# Altium schematic sheet RelayCard_LED_Monitor.SchDoc
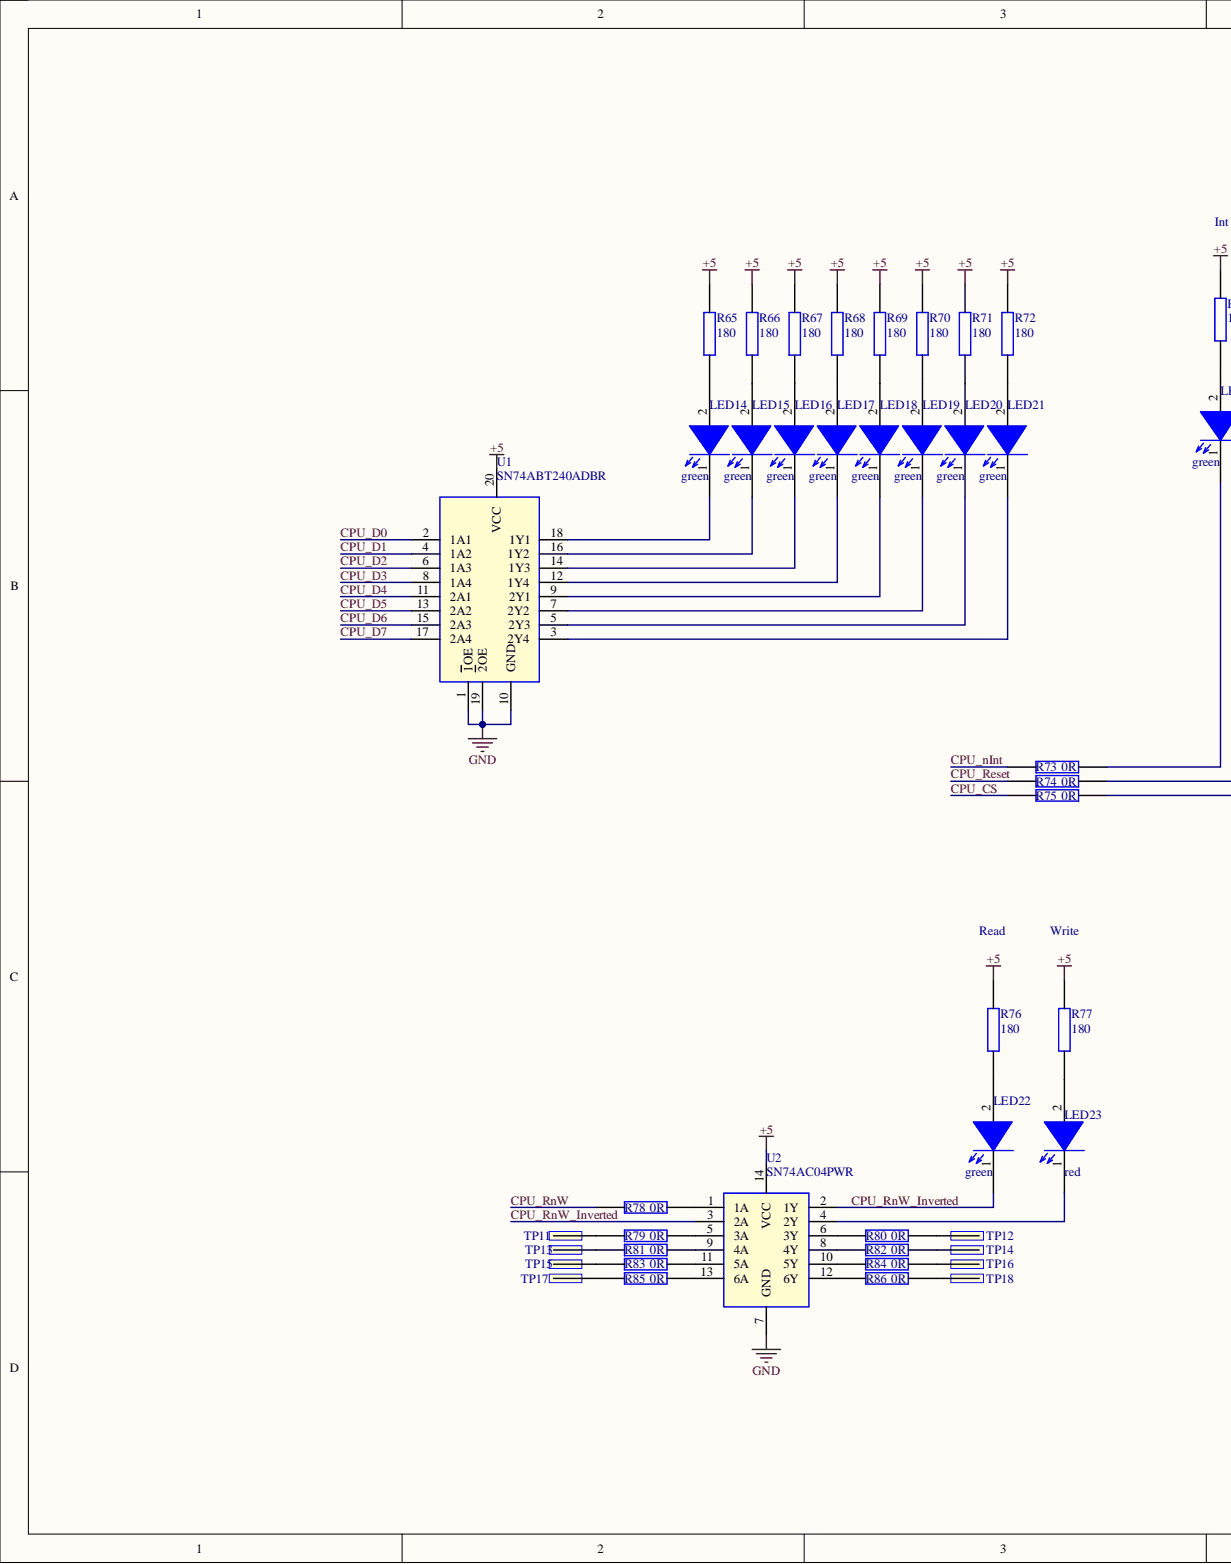
[diagram p0: RelayCard_LED_Monitor.SchDoc, left]
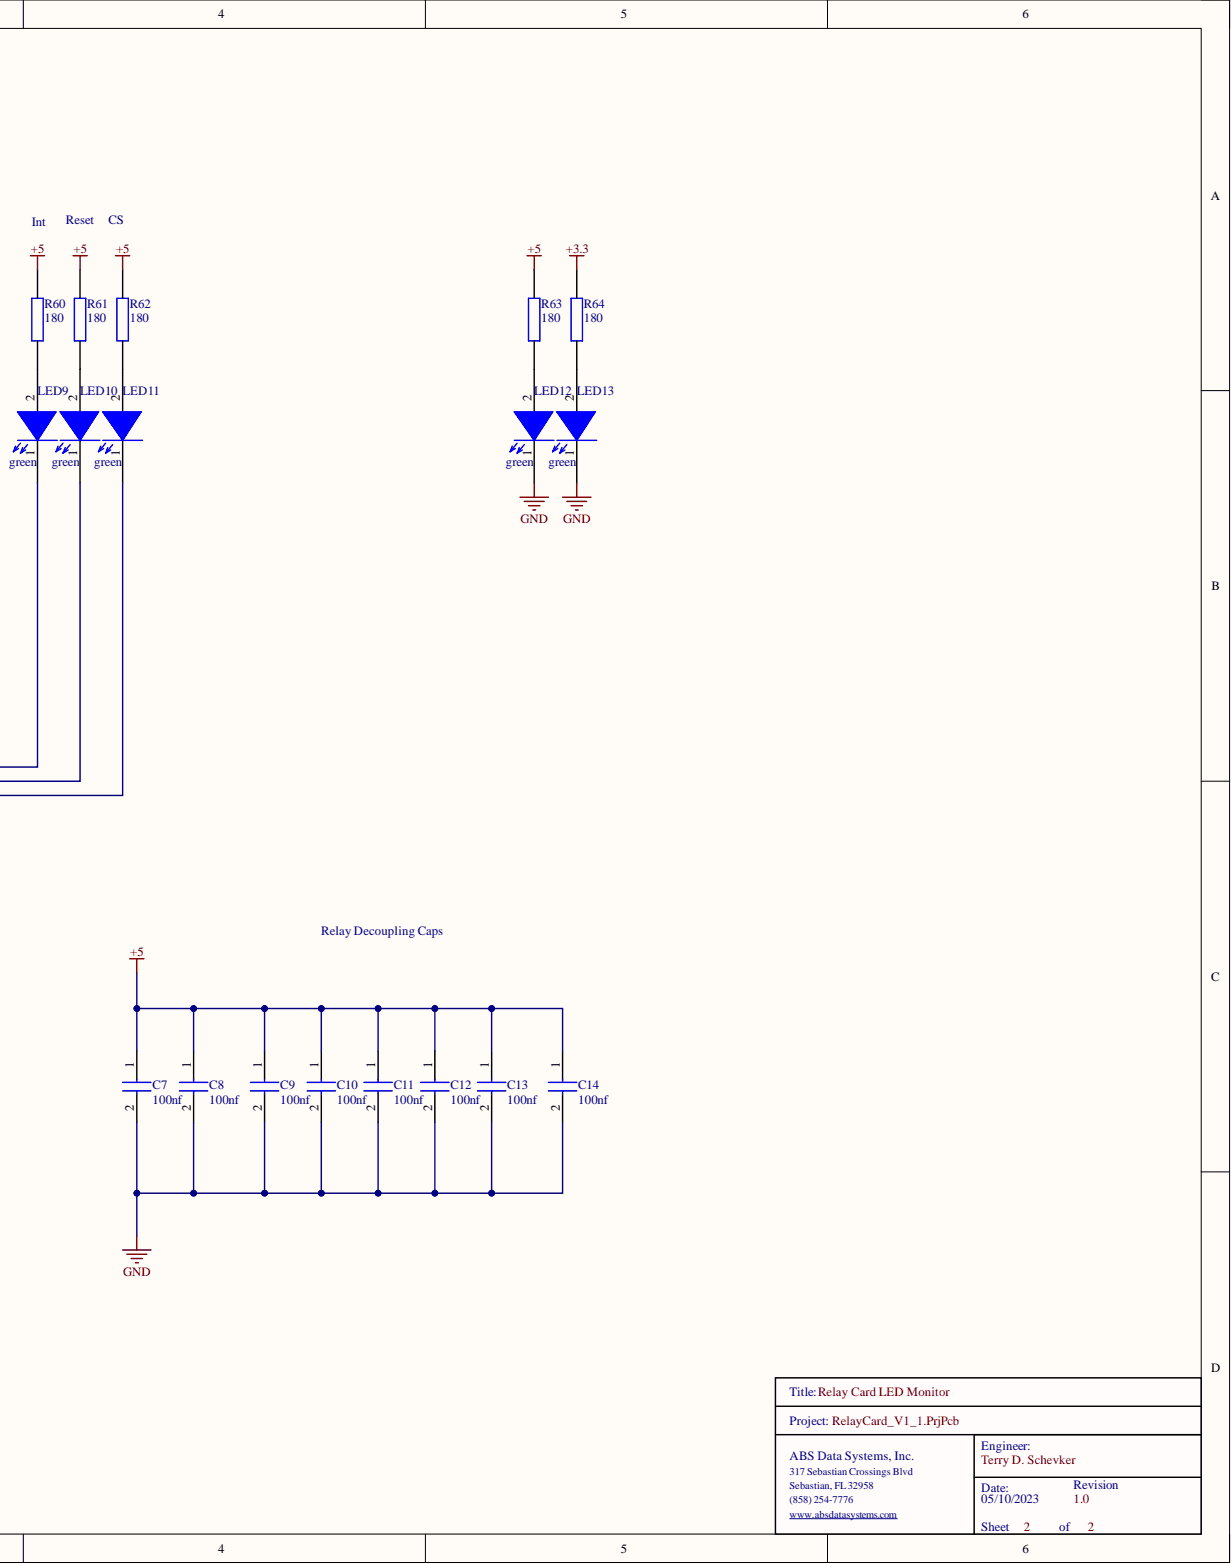
[diagram p1: RelayCard_LED_Monitor.SchDoc, right]

## Parts
C7  100nf  [0603]
C8  100nf  [0603]
C9  100nf  [0603]
C10  100nf  [0603]
C11  100nf  [0603]
C12  100nf  [0603]
C13  100nf  [0603]
C14  100nf  [0603]
LED9  green  [0603_Diode]
LED10  green  [0603_Diode]
LED11  green  [0603_Diode]
LED12  green  [0603_Diode]
LED13  green  [0603_Diode]
LED14  green  [0603_Diode]
LED15  green  [0603_Diode]
LED16  green  [0603_Diode]
LED17  green  [0603_Diode]
LED18  green  [0603_Diode]
LED19  green  [0603_Diode]
LED20  green  [0603_Diode]
LED21  green  [0603_Diode]
LED22  green  [0603_Diode]
LED23  red  [0603_Diode]
R60  180  [0603]
R61  180  [0603]
R62  180  [0603]
R63  180  [0603]
R64  180  [0603]
R65  180  [0603]
R66  180  [0603]
R67  180  [0603]
R68  180  [0603]
R69  180  [0603]
R70  180  [0603]
R71  180  [0603]
R72  180  [0603]
R73  0R  [0603]
R74  0R  [0603]
R75  0R  [0603]
R76  180  [0603]
R77  180  [0603]
R78  0R  [0603]
R79  0R  [0603]
R80  0R  [0603]
R81  0R  [0603]
R82  0R  [0603]
R83  0R  [0603]
R84  0R  [0603]
R85  0R  [0603]
R86  0R  [0603]
TP11  TP  [TP]
TP12  TP  [TP]
TP13  TP  [TP]
TP14  TP  [TP]
TP15  TP  [TP]
TP16  TP  [TP]
TP17  TP  [TP]
TP18  TP  [TP]
U1  SN74ABT240ADBR  [SOP65P780X200-20N]
U2  SN74AC04PWR  [SOP65P640X120-14N]

## Nets
+3.3: R64.2
+5: C7.1 C8.1 C9.1 C10.1 C11.1 C12.1 C13.1 C14.1 R60.2 R61.2 R62.2 R63.2 R65.2 R66.2 R67.2 R68.2 R69.2 R70.2 R71.2 R72.2 R76.2 R77.2 U1.20(VCC) U2.14(VCC)
GND: C7.2 C8.2 C9.2 C10.2 C11.2 C12.2 C13.2 C14.2 LED12.1 LED13.1 U1.1(1OE) U1.10(GND) U1.19(2OE) U2.7(GND)
CPU_CS: R75.1
CPU_D0: U1.2(1A1)
CPU_D1: U1.4(1A2)
CPU_D2: U1.6(1A3)
CPU_D3: U1.8(1A4)
CPU_D4: U1.11(2A1)
CPU_D5: U1.13(2A2)
CPU_D6: U1.15(2A3)
CPU_D7: U1.17(2A4)
CPU_nInt: R73.1
CPU_Reset: R74.1
CPU_RnW: R78.1
CPU_RnW_Inverted: LED22.1 U2.2(1Y) U2.3(2A)
NetLED9_1: LED9.1 R73.2
NetLED9_2: LED9.2 R60.1
NetLED10_1: LED10.1 R74.2
NetLED10_2: LED10.2 R61.1
NetLED11_1: LED11.1 R75.2
NetLED11_2: LED11.2 R62.1
NetLED12_2: LED12.2 R63.1
NetLED13_2: LED13.2 R64.1
NetLED14_1: LED14.1 U1.18(1Y1)
NetLED14_2: LED14.2 R65.1
NetLED15_1: LED15.1 U1.16(1Y2)
NetLED15_2: LED15.2 R66.1
NetLED16_1: LED16.1 U1.14(1Y3)
NetLED16_2: LED16.2 R67.1
NetLED17_1: LED17.1 U1.12(1Y4)
NetLED17_2: LED17.2 R68.1
NetLED18_1: LED18.1 U1.9(2Y1)
NetLED18_2: LED18.2 R69.1
NetLED19_1: LED19.1 U1.7(2Y2)
NetLED19_2: LED19.2 R70.1
NetLED20_1: LED20.1 U1.5(2Y3)
NetLED20_2: LED20.2 R71.1
NetLED21_1: LED21.1 U1.3(2Y4)
NetLED21_2: LED21.2 R72.1
NetLED22_2: LED22.2 R76.1
NetLED23_1: LED23.1 U2.4(2Y)
NetLED23_2: LED23.2 R77.1
NetR78_2: R78.2 U2.1(1A)
NetR79_1: R79.1 TP11.1
NetR79_2: R79.2 U2.5(3A)
NetR80_1: R80.1 U2.6(3Y)
NetR80_2: R80.2 TP12.1
NetR81_1: R81.1 TP13.1
NetR81_2: R81.2 U2.9(4A)
NetR82_1: R82.1 U2.8(4Y)
NetR82_2: R82.2 TP14.1
NetR83_1: R83.1 TP15.1
NetR83_2: R83.2 U2.11(5A)
NetR84_1: R84.1 U2.10(5Y)
NetR84_2: R84.2 TP16.1
NetR85_1: R85.1 TP17.1
NetR85_2: R85.2 U2.13(6A)
NetR86_1: R86.1 U2.12(6Y)
NetR86_2: R86.2 TP18.1

## Library data of the parts
C7-C14 = CL10B104JB8NNNC: Samsung Electro-Mechanics 0603 100nf; Component Kind: Standard; Library Name: Capacitors.SchLib
LED9-LED22 = 150060GS75000: Wurth Elektronik 0603 green; Manufacturer: Wurth Electronics; Dominant Wavelength: 520nm; Forward Current: 30mA; Forward Voltage: 3.2V; Lens Style: Clear,Rectangular; Luminous Intensity: 430mcd; Test Current: 20mA; Viewing Angle: 140°; Case Code (Imperial): 0603; Case Code (Metric): 1608; Case/Package: 0603; Category: LEDs; Color: Green; Component Kind: Standard; ComponentLink1Description: https://datasheet.ciiva.com/29562/150060gs75000-29562868.pdf?src-supplier=Digi-Key; ComponentLink1URL: https://datasheet.ciiva.com/29562/150060gs75000-29562868.pdf?src-supplier=Digi-Key; ComponentLink2Description: https://datasheet.ciiva.com/29279/759427-da-01-en-chipled-0603-w-kl-gruen-150060gs75000-29279201.pdf?src-supplier=Conrad; ComponentLink2URL: https://datasheet.ciiva.com/29279/759427-da-01-en-chipled-0603-w-kl-gruen-150060gs75000-29279201.pdf?src-supplier=Conrad; ComponentLink3Description: https://datasheet.ciiva.com/29562/150060gs75000-29562869.pdf?src-supplier=Digi-Key; ComponentLink3URL: https://datasheet.ciiva.com/29562/150060gs75000-29562869.pdf?src-supplier=Digi-Key; ComponentLink4Description: https://datasheet.ciiva.com/29562/150060gs75000-29562870.pdf?src-supplier=Digi-Key; ComponentLink4URL: https://datasheet.ciiva.com/29562/150060gs75000-29562870.pdf?src-supplier=Digi-Key; Height: 0.7mm; Lead Free: Lead Free; Length: 1.6mm; Library Name: Misc.SchLib; Manufacturer Part Number: 150060GS75000; Max Operating Temperature: 85°C; Min Operating Temperature: -40°C; Mount: Surface Mount; Package Quantity: 4000; Packaging: Tape and Reel; Pricing 1: 1=0.14000, 50=0.13300, 100=0.11400, 500=0.09900 (USD); REACH SVHC: Unknown; RoHS: Yes; Stock 1: 71509; Supplier 1: Digi-Key; Wavelength: 520nm; Width: 0.8mm
LED23 = 150060RS75000: Wurth Elektronik 0603 red; Manufacturer: Wurth Electronics; Manufacturer Part Number: 150060GS75000; Color: Green; Dominant Wavelength: 520nm; Forward Current: 30mA; Forward Voltage: 3.2V; Lens Style: Clear,Rectangular; Luminous Intensity: 430mcd; Test Current: 20mA; Viewing Angle: 140°; Case Code (Imperial): 0603; Case Code (Metric): 1608; Case/Package: 0603; Category: LEDs; Component Kind: Standard; ComponentLink1Description: https://datasheet.ciiva.com/29562/150060gs75000-29562868.pdf?src-supplier=Digi-Key; ComponentLink1URL: https://datasheet.ciiva.com/29562/150060gs75000-29562868.pdf?src-supplier=Digi-Key; ComponentLink2Description: https://datasheet.ciiva.com/29279/759427-da-01-en-chipled-0603-w-kl-gruen-150060gs75000-29279201.pdf?src-supplier=Conrad; ComponentLink2URL: https://datasheet.ciiva.com/29279/759427-da-01-en-chipled-0603-w-kl-gruen-150060gs75000-29279201.pdf?src-supplier=Conrad; ComponentLink3Description: https://datasheet.ciiva.com/29562/150060gs75000-29562869.pdf?src-supplier=Digi-Key; ComponentLink3URL: https://datasheet.ciiva.com/29562/150060gs75000-29562869.pdf?src-supplier=Digi-Key; ComponentLink4Description: https://datasheet.ciiva.com/29562/150060gs75000-29562870.pdf?src-supplier=Digi-Key; ComponentLink4URL: https://datasheet.ciiva.com/29562/150060gs75000-29562870.pdf?src-supplier=Digi-Key; Height: 0.7mm; Lead Free: Lead Free; Length: 1.6mm; Library Name: Misc.SchLib; Max Operating Temperature: 85°C; Min Operating Temperature: -40°C; Mount: Surface Mount; Package Quantity: 4000; Packaging: Tape and Reel; Pricing 1: 1=0.14000, 50=0.13300, 100=0.11400, 500=0.09900 (USD); REACH SVHC: Unknown; RoHS: Yes; Stock 1: 71509; Supplier 1: Digi-Key; Wavelength: 520nm; Width: 0.8mm
R60-R72 R76 R77 = RC0603FR-13180RL: YAGEO 0603 180 ohm; Manufacturer_Name: KEMET; Manufacturer_Part_Number: RC0603JR-070RL; Geometry.Height: 0.55mm; Component Kind: Standard; Datasheet Link: https://datasheet.datasheetarchive.com/originals/distributors/Datasheets_SAMA/902f9e387b938f871d31120f5fc1d65e.pdf; Library Name: Resistors.SchLib; Mouser Part Number: 603-RC0603JR-070RL; Mouser Price/Stock: https://www.mouser.co.uk/ProductDetail/YAGEO/RC0603JR-070RL?qs=2cAdsCoAWRGWyPcuXgGiXQ%3D%3D
R73-R75 R78-R86 = RC0603JR-070RL: YAGEO 0603 0 ohm; Manufacturer_Name: KEMET; Geometry.Height: 0.55mm; Component Kind: Standard; Datasheet Link: https://datasheet.datasheetarchive.com/originals/distributors/Datasheets_SAMA/902f9e387b938f871d31120f5fc1d65e.pdf; Library Name: Resistors.SchLib; Manufacturer_Part_Number: RC0603JR-070RL; Mouser Part Number: 603-RC0603JR-070RL; Mouser Price/Stock: https://www.mouser.co.uk/ProductDetail/YAGEO/RC0603JR-070RL?qs=2cAdsCoAWRGWyPcuXgGiXQ%3D%3D
TP11-TP18 = TP: Manufacturer: Samtec; Manufacturer Part Number: TST-105-01-G-D; Connector Type: Male Pin; Gender: Male; Number of Contacts: 16; Pitch: 2.54mm; Row Spacing: 0.1inch; Category: Headers and Wire Housings; Color: Black; Component Kind: Standard; ComponentLink1Description: https://datasheet.ciiva.com/739/tst-mkt-739845.pdf?src-supplier=Digi-Key; ComponentLink1URL: https://datasheet.ciiva.com/739/tst-mkt-739845.pdf?src-supplier=Digi-Key; ComponentLink2Description: https://datasheet.ciiva.com/10018/htst-10018510.pdf?src-supplier=Avnet; ComponentLink2URL: https://datasheet.ciiva.com/10018/htst-10018510.pdf?src-supplier=Avnet; Contact Material: Bronze; Contact Mating Length: 0.25inch; Contact Plating: Gold; Depth: 9.27mm; Housing Color: Black; Housing Material: Polyester; Length: 27.94mm; Library Name: Misc.SchLib; Max Operating Temperature: 125°C; Min Operating Temperature: -55°C; Mount: Through Hole; Number of Positions: 16; Number of Rows: 2; Orientation: Straight; Packaging: Rail/Tube; Pricing 1: 1=2.85000, 10=2.73600, 100=2.28000, 500=1.93800, 1000=1.65300, 5000=1.48200 (USD); REACH SVHC: No SVHC; RoHS: Yes; Stock 1: 227; Supplier 1: Digi-Key; Termination: Solder
U1 = SN74ABT240ADBR: Octal Buffers/Drivers With 3-State Outputs; Manufacturer_Name: Texas Instruments; Geometry.Height: 2mm; Component Kind: Standard; Datasheet Link: http://www.ti.com/lit/gpn/sn74abt240a; Library Name: Logic.SchLib; Manufacturer_Part_Number: SN74ABT240ADBR; Mouser Part Number: 595-SN74ABT240ADBR; Mouser Price/Stock: https://www.mouser.co.uk/ProductDetail/Texas-Instruments/SN74ABT240ADBR?qs=JHHQeKcAU3Dt82k%252BVyiYdw%3D%3D
U2 = SN74AC04PWR: Hex Inverters; Manufacturer_Name: Texas Instruments; Geometry.Height: 1.2mm; Component Kind: Standard; Datasheet Link: http://www.ti.com/lit/gpn/sn74ac04; Library Name: Logic.SchLib; Manufacturer_Part_Number: SN74AC04PWR; Mouser Part Number: 595-SN74AC04PWR; Mouser Price/Stock: https://www.mouser.co.uk/ProductDetail/Texas-Instruments/SN74AC04PWR?qs=RZS%252BpEsUn7pRvPNqsga4SA%3D%3D
